# Altium schematic sheet Kit_Rele_v1_SCH.SchDoc(Kit_Rele_v1_SCH)
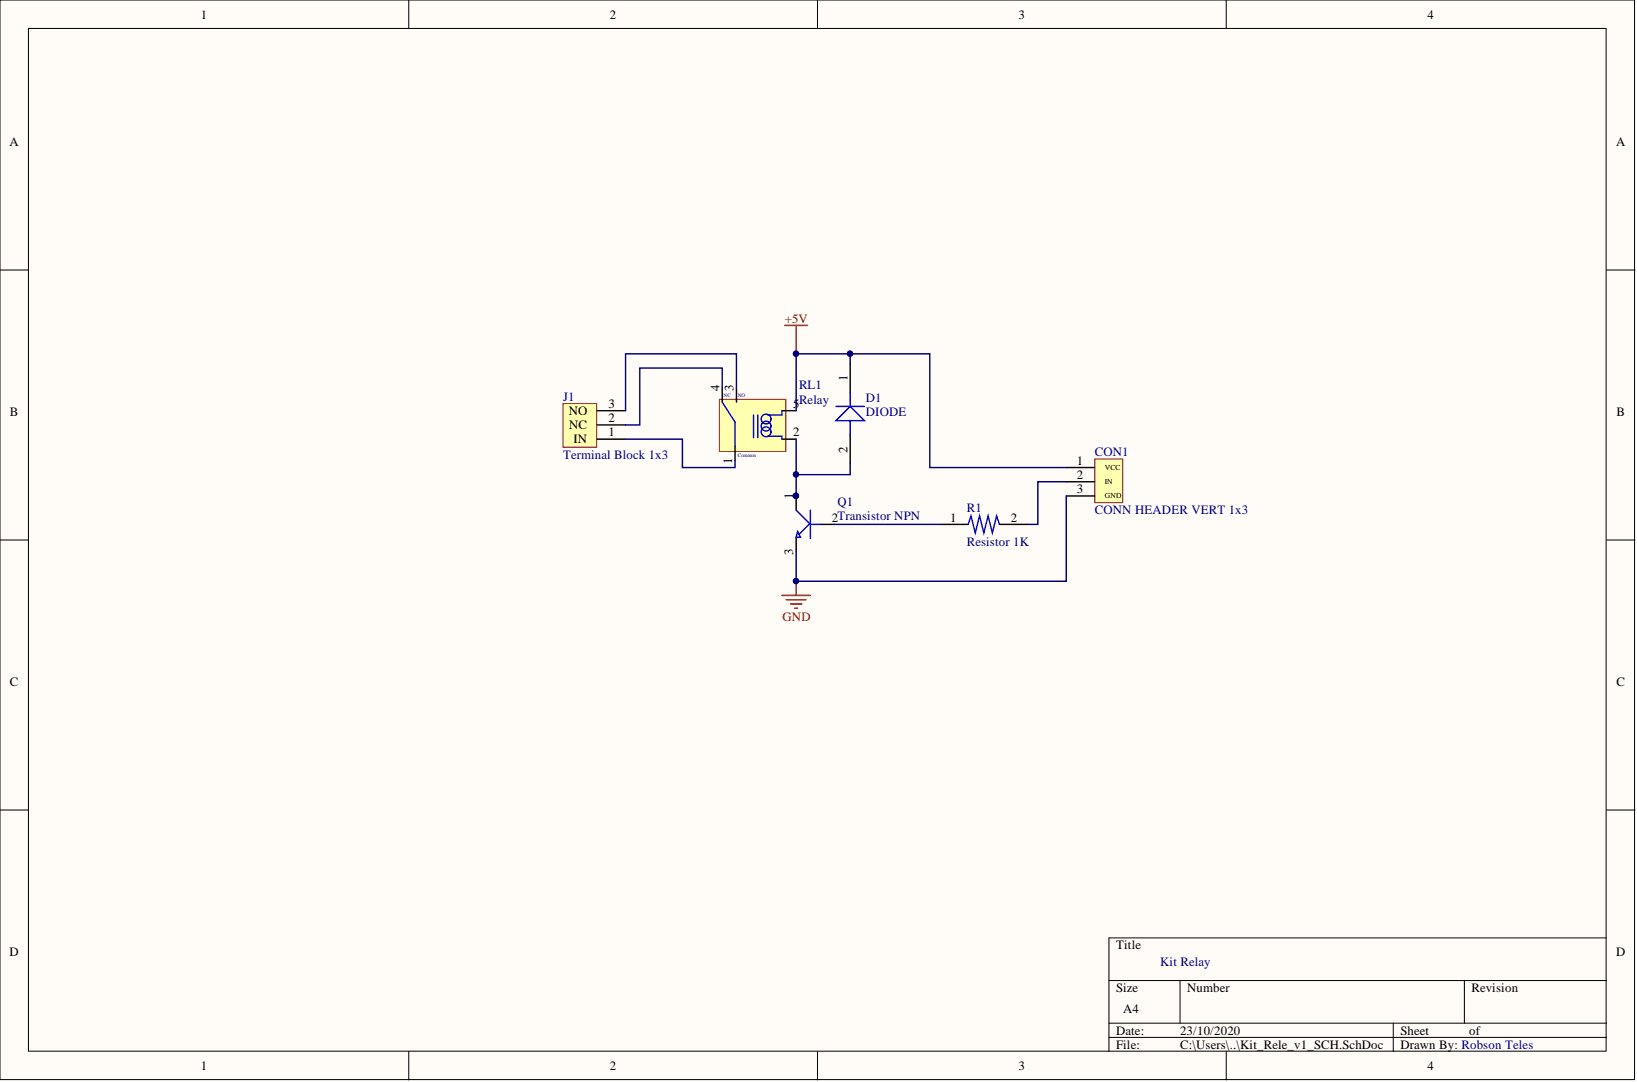
[diagram p0: Kit_Rele_v1_SCH.SchDoc]

## Parts
CON1  CONN HEADER VERT 1x3  [CONN HEADER]
D1  DIODE  [DIODE BAT54]
J1  Terminal Block 1x3  [Terminal Block]
Q1  Transistor NPN  Category: Transistor  [Transistor SOT-23]
R1  Resistor 1K  [R1206]
RL1  Relay  [Relay]

## Nets
+5V: CON1.1 D1.1 RL1.5
GND: CON1.3 Q1.3
NetCON1_2: CON1.2 R1.2
NetD1_2: D1.2 Q1.1 RL1.2
NetJ1_1: J1.1 RL1.1
NetJ1_2: J1.2 RL1.4
NetJ1_3: J1.3 RL1.3
NetQ1_2: Q1.2 R1.1

## Library data of the parts
CON1 = 61300311121: Board-To-Board Connector, Vertical, Wr-Phd Series, Through Hole, Header, 3, 2.54 Mm; Manufacturer: Wurth Electronics; Pitch: 0.1inch; Number of Positions: 3; Gender: Male; Cotegory: Connectors; Connector Type: Male Pin; Termination: Solder; Supplier Part Number 1: 732-5316-ND; Supplier 1: Digi-Key; Stock 1: 109382; REACH SVHC: Unknown; Pricing 1: 1=0.13, 10=0.106, 25=0.088, 50=0.074, 100=0.067, 1000=0.061 (USD); Packaging: Bulk; Number of Rows: 1; Number of Contacts: 3; Mount: Through Hole; Manufacturer Part Number: 61300311121; Lead Free: Lead Free; Contact Plating: Gold; Contact Mating Length: 0.236inch; Contact Material: Copper; ComponentLink2URL: https://katalog.we-online.de/em/datasheet/6130xx11121.pdf?src-supplier=Digi-Key; ComponentLink2Description: https://katalog.we-online.de/em/datasheet/6130xx11121.pdf?src-supplier=Digi-Key; ComponentLink1URL: http://www.farnell.com/cad/1737268.pdf?src-supplier=Element14; ComponentLink1Description: http://www.farnell.com/cad/1737268.pdf?src-supplier=Element14; Component Kind: Standard; Color: Black; Library Name: Kit_Rele_v1_SCHLib.SchLib
D1 = BAT54HT1G: Small Signal Schottky Diode, Single, 30 V, 200 mA, 800 mV, 600 mA, 150 C ;RoHS Compliant: Yes; Manufacturer: ON Semiconductor / Fairchild; Voltage Rating (DC): 30V; Reverse Recovery Time: 5ns; Power Dissipation: 200mW; Polarity: Standard; Peak Reverse Current: 2uA; Peak Non-Repetitive Surge Current: 600mA; Output Current: 200mA; Forward Voltage: 800mV; Capacitance: 10pF; Width: 1.35mm; Supplier Part Number 1: BAT54HT1GOSCT-ND; Supplier 1: Digi-Key; Stock 1: 221756; Reverse Voltage: 30V; Recovery Time: 5ns; REACH SVHC: No SVHC; Radiation Hardening: No; Pricing 1: 1=0.2, 10=0.181, 25=0.1724, 100=0.0759, 500=0.05962, 1000=0.0414 (USD); Packaging: Tape and Reel; Package Quantity: 3000; Number of Pins: 2; Mount: Surface Mount; Min Operating Temperature: -55°C; Max Surge Current: 600mA; Max Reverse Voltage (DC): 30V; Max Reverse Leakage Current: 2uA; Max Repetitive Reverse Voltage (Vrrm): 30V; Max Operating Temperature: 150°C; Max Forward Surge Current (Ifsm): 600mA; Manufacturer Part Number: BAT54HT1G; Length: 1.8mm; Lead Free: Lead Free; Height: 1mm; Forward Current: 200mA; Element Configuration: Single; Current Rating: 200mA; Contact Plating: Tin,Matte; ComponentLink13URL: http://www.produktinfo.conrad.com/datenblaetter/1200000-1299999/001262925-da-01-en-DIODE_SCHOTTKY_30V_BAT54HT1G_SOD_323_FSC.pdf?src-supplier=Conrad; ComponentLink13Description: http://www.produktinfo.conrad.com/datenblaetter/1200000-1299999/001262925-da-01-en-DIODE_SCHOTTKY_30V_BAT54HT1G_SOD_323_FSC.pdf?src-supplier=Conrad; ComponentLink12URL: http://www.fairchildsemi.com/ds/BA/BAT54HT1G.pdf?src-supplier=Future+Electronics; ComponentLink12Description: http://www.fairchildsemi.com/ds/BA/BAT54HT1G.pdf?src-supplier=Future+Electronics; ComponentLink11URL: https://media.digikey.com/pdf/Data%20Sheets/Fairchild%20PDFs/BAT54HT1G_Rev_1.2.pdf?src-supplier=Mouser; ComponentLink11Description: https://media.digikey.com/pdf/Data%20Sheets/Fairchild%20PDFs/BAT54HT1G_Rev_1.2.pdf?src-supplier=Mouser; ComponentLink10URL: http://uk.rs-online.com/webdocs/11a6/0900766b811a63a9.pdf?src-supplier=RSComponents; ComponentLink10Description: http://uk.rs-online.com/webdocs/11a6/0900766b811a63a9.pdf?src-supplier=RSComponents; ComponentLink9URL: http://www.onsemi.com/pub/Collateral/BAT54HT1-D.PDF?src-supplier=Digi-Key; ComponentLink9Description: http://www.onsemi.com/pub/Collateral/BAT54HT1-D.PDF?src-supplier=Digi-Key; ComponentLink8URL: http://www.onsemi.com/pub/Collateral/BAT54HT1-D.PDF?src-supplier=Avnet; ComponentLink8Description: http://www.onsemi.com/pub/Collateral/BAT54HT1-D.PDF?src-supplier=Avnet; ComponentLink7URL: https://media.digikey.com/pdf/Data%20Sheets/Fairchild%20PDFs/BAT54HT1G_Rev_1.2.pdf?src-supplier=Avnet; ComponentLink7Description: https://media.digikey.com/pdf/Data%20Sheets/Fairchild%20PDFs/BAT54HT1G_Rev_1.2.pdf?src-supplier=Avnet; ComponentLink6URL: http://www.farnell.com/datasheets/1727620.pdf?src-supplier=Element14; ComponentLink6Description: http://www.farnell.com/datasheets/1727620.pdf?src-supplier=Element14; ComponentLink5URL: http://www.mouser.com/ds/2/149/BAT54HT1G-104602.pdf?src-supplier=Mouser; ComponentLink5Description: http://www.mouser.com/ds/2/149/BAT54HT1G-104602.pdf?src-supplier=Mouser; ComponentLink4URL: http://www.farnell.com/datasheets/2251626.pdf?src-supplier=Newark; ComponentLink4Description: http://www.farnell.com/datasheets/2251626.pdf?src-supplier=Newark; ComponentLink3URL: http://www.jameco.com/Jameco/Products/ProdDS/806549.pdf?src-supplier=Jameco; ComponentLink3Description: http://www.jameco.com/Jameco/Products/ProdDS/806549.pdf?src-supplier=Jameco; ComponentLink2URL: http://www.farnell.com/datasheets/2251626.pdf?src-supplier=Element14; ComponentLink2Description: http://www.farnell.com/datasheets/2251626.pdf?src-supplier=Element14; ComponentLink1URL: http://www.fairchildsemi.com/datasheets/BA/BAT54HT1G.pdf?src-supplier=Digi-Key; ComponentLink1Description: http://www.fairchildsemi.com/datasheets/BA/BAT54HT1G.pdf?src-supplier=Digi-Key; Component Kind: Standard; Category: Diode; Average Rectified Current: 200mA; Library Name: Kit_Rele_v1_SCHLib.SchLib
J1 = 1935174: PHOENIX CONTACT - PT1,5/3-5.0-H - Wire-To-Board Terminal Block, 5 mm, 3 Ways, 26 AWG, 16 AWG, 1.5 mm², Screw; Wire Gauge (Min): 12AWG; Wire Gauge (Max): 26AWG; Voltage Rating (DC): 320V; Voltage Rating: 250V; Voltage: 300V; Stripping Length: 5mm; Number of Positions Per Level: 3; Number of Levels: 1; Max Current Rating: 16A; Current Rating: 17.5A; Current: 18A; Approvals: UL,VDE,CB; Wire/Cable Gauge: 26AWG; Width: 15mm; Weight: 0.100531oz; Termination: Solder; Supplier Part Number 1: 277-1578-ND; Supplier 1: Digi-Key; Stock 1: 27165; REACH SVHC: Unknown; Radiation Hardening: No; Pricing 1: 1=0.6, 10=0.58, 50=0.5544, 100=0.5393, 250=0.504, 500=0.46368, 1000=0.4284, 2500=0.42838 (USD); Pitch: 5mm; Packaging: Bulk; Package Quantity: 250; Orientation: Straight; Number of Rows: 1; Number of Positions: 3; Number of Poles: 3; Number of Pins: 3; Number of Contacts: 3; Number of Circuits: 3; Mount: Through Hole; Max Supply Voltage: 250V; Material: Nylon; Manufacturer Part Number: 1935174; Manufacturer: Phoenix Contact; Length: 9mm; Lead Free: Lead Free; Insulation Material: Nylon; Housing Material: Polyamide; Housing Color: Green; Height: 11.3mm; Flammability Rating: UL94 V-0; Depth: 9mm; Contact Material: Copper,Tin; ComponentLink3URL: https://produktinfo.conrad.com/datenblaetter/725000-749999/744167-da-01-en-PRINTKLEMME_PT_1_5__3_5_0_H.pdf?src-supplier=Conrad; ComponentLink3Description: https://produktinfo.conrad.com/datenblaetter/725000-749999/744167-da-01-en-PRINTKLEMME_PT_1_5__3_5_0_H.pdf?src-supplier=Conrad; ComponentLink2URL: https://www.verical.com/server-webapp/html/getDatasheet?partID=212213&src-supplier=Verical; ComponentLink2Description: https://www.verical.com/server-webapp/html/getDatasheet?partID=212213&src-supplier=Verical; ComponentLink1URL: https://media.digikey.com/pdf/Data%20Sheets/Phoenix%20Contact%20PDFs/1935174.pdf?src-supplier=Digi-Key; ComponentLink1Description: https://media.digikey.com/pdf/Data%20Sheets/Phoenix%20Contact%20PDFs/1935174.pdf?src-supplier=Digi-Key; Component Kind: Standard; Color: Green; Category: Connectors; Library Name: Kit_Rele_v1_SCHLib.SchLib
Q1 = MMBT3904-TP: TRANS NPN 40V 0.2A SOT23; Manufacturer: MCC; Transition Frequency: 300MHz; Power Dissipation: 350mW; Number of Elements: 1; Max Collector Current: 200mA; hFE Min: 40; Emitter Base Voltage (VEBO): 6V; Element Configuration: Single; Current Rating: 100mA; Collector Emitter Saturation Voltage: 300mV; Collector Base Voltage (VCBO): 60V; Width: 1.4mm; Voltage Rating (DC): 40V; Supplier Part Number 1: MMBT3904TPMSDKR-ND; Supplier 1: Digi-Key; Stock 1: 530270; Radiation Hardening: No; Pricing 1: 1=0.1, 10=0.076, 25=0.0724, 100=0.0319, 500=0.02506, 1000=0.0174 (USD); Polarity: NPN; Packaging: Tape and Reel; Package Quantity: 1; Number of Pins: 3; Mount: Surface Mount; Min Operating Temperature: -55°C; Max Power Dissipation: 350mW; Max Operating Temperature: 150°C; Max Frequency: 300MHz; Max Breakdown Voltage: 40V; Manufacturer Part Number: MMBT3904-TP; Length: 3.04mm; Lead Free: Lead Free; Height: 1.12mm; Gain Bandwidth Product: 300MHz; Frequency: 300MHz; ComponentLink5URL: https://www.verical.com/server-webapp/html/getDatasheet?partID=251950&src-supplier=Verical; ComponentLink5Description: https://www.verical.com/server-webapp/html/getDatasheet?partID=251950&src-supplier=Verical; ComponentLink4URL: http://www.mouser.com/ds/2/258/MMBT3904(SOT-23)-350032.pdf?src-supplier=Mouser; ComponentLink4Description: http://www.mouser.com/ds/2/258/MMBT3904(SOT-23)-350032.pdf?src-supplier=Mouser; ComponentLink3URL: http://www.mccsemi.com/up_pdf/MMBT3904T(SOT-523).PDF?src-supplier=Future+Electronics; ComponentLink3Description: http://www.mccsemi.com/up_pdf/MMBT3904T(SOT-523).PDF?src-supplier=Future+Electronics; ComponentLink2URL: http://www.alliedelec.com/images/products/datasheets/bm/MCC/70055699.pdf?src-supplier=Allied+Electronics; ComponentLink2Description: http://www.alliedelec.com/images/products/datasheets/bm/MCC/70055699.pdf?src-supplier=Allied+Electronics; ComponentLink1URL: http://www.mccsemi.com/up_pdf/MMBT3904(SOT-23).pdf?src-supplier=Digi-Key; ComponentLink1Description: http://www.mccsemi.com/up_pdf/MMBT3904(SOT-23).pdf?src-supplier=Digi-Key; Component Kind: Standard; Collector Emitter Voltage (VCEO): 40V; Collector Emitter Breakdown Voltage: 40V; Case/Package: SOT-23; Library Name: Kit_Rele_v1_SCHLib.SchLib
R1 = RC1206JR-071KL: RES SMD 1K OHM 5% 1/4W 1206; Manufacturer: Yageo; Voltage Rating: 200V; Temperature Coefficient: 100ppm/°C; Resistance: 1kR; Power Rating: 250mW; Width: 0.063inch; Tolerance: 5%; Termination: SMD/SMT; Supplier Part Number 1: 311-1.0KERDKR-ND; Supplier 1: Digi-Key; Stock 1: 2036007; REACH SVHC: Unknown; Pricing 1: 1=0.1, 10=0.059, 100=0.024, 1000=0.01079, 2500=0.00936 (USD); Packaging: Tape and Reel; Package Quantity: 1; Number of Terminations: 2; Min Operating Temperature: -55°C; Military Standard: Not; Max Power Dissipation: 250mW; Max Operating Temperature: 155°C; Manufacturer Part Number: RC1206JR-071KL; Length: 3.1mm; Lead Free: Lead Free; Height: 0.026inch; Depth: 1.6mm; Composition: Thick Film; ComponentLink2URL: http://www.yageo.com/documents/recent/PYu-RC_Group_51_RoHS_L_9.pdf?src-supplier=Digi-Key; ComponentLink2Description: http://www.yageo.com/documents/recent/PYu-RC_Group_51_RoHS_L_9.pdf?src-supplier=Digi-Key; ComponentLink1URL: http://www.yageo.com/documents/recent/PYu-RC_Group_51_RoHS_L_10.pdf?src-supplier=Digi-Key; ComponentLink1Description: http://www.yageo.com/documents/recent/PYu-RC_Group_51_RoHS_L_10.pdf?src-supplier=Digi-Key; Component Kind: Standard; Category: Chip Resistor - Surface Mount; Case Code (Metric): 3216; Case Code (Imperial): 1206; Case/Package: 1206; Library Name: Kit_Rele_v1_SCHLib.SchLib
RL1 = G5LE-1-36DC5: RELAY GEN PURPOSE SPDT 10A 5V; Manufacturer: Omron; Release Time: 5ms; Relay Type: General Purpose; Operate Time: 10ms; Max Voltage Rating (DC): 125V; Max Voltage Rating (AC): 250V; Coil Type: Non-Latching; Coil Resistance: 70R; Coil Power: 360mW; Coil Current: 72mA; Weight: 12g; Throw Configuration: SPDT; Termination: PC Pin; Supplier Part Number 1: G5LE-1-36DC5-ND; Supplier 1: Digi-Key; Stock 1: 186; Pricing 1: 1=1.45, 10=1.361, 25=1.21, 50=1.1494, 100=1.0888, 250=0.96784, 500=0.90736, 1000=0.84686, 5000=0.81662 (USD); Packaging: Tray; Package Quantity: 500; Number of Pins: 5; Mount: Through Hole; Min Operating Temperature: -25°C; Max Operating Temperature: 85°C; Max Current Rating: 10A; Manufacturer Part Number: G5LE-1-36DC5; Library Name: Kit_Rele_v1_SCHLib.SchLib; Contact Material: Tin,Silver; Contact Current Rating: 10A; ComponentLink1URL: https://omronfs.omron.com/en_US/ecb/products/pdf/en-g5le.pdf?src-supplier=Digi-Key; ComponentLink1Description: https://omronfs.omron.com/en_US/ecb/products/pdf/en-g5le.pdf?src-supplier=Digi-Key; Component Kind: Standard; Coil Voltage (DC): 5V; Category: Relays
